# Altium schematic sheet 03.ETC.SchDoc
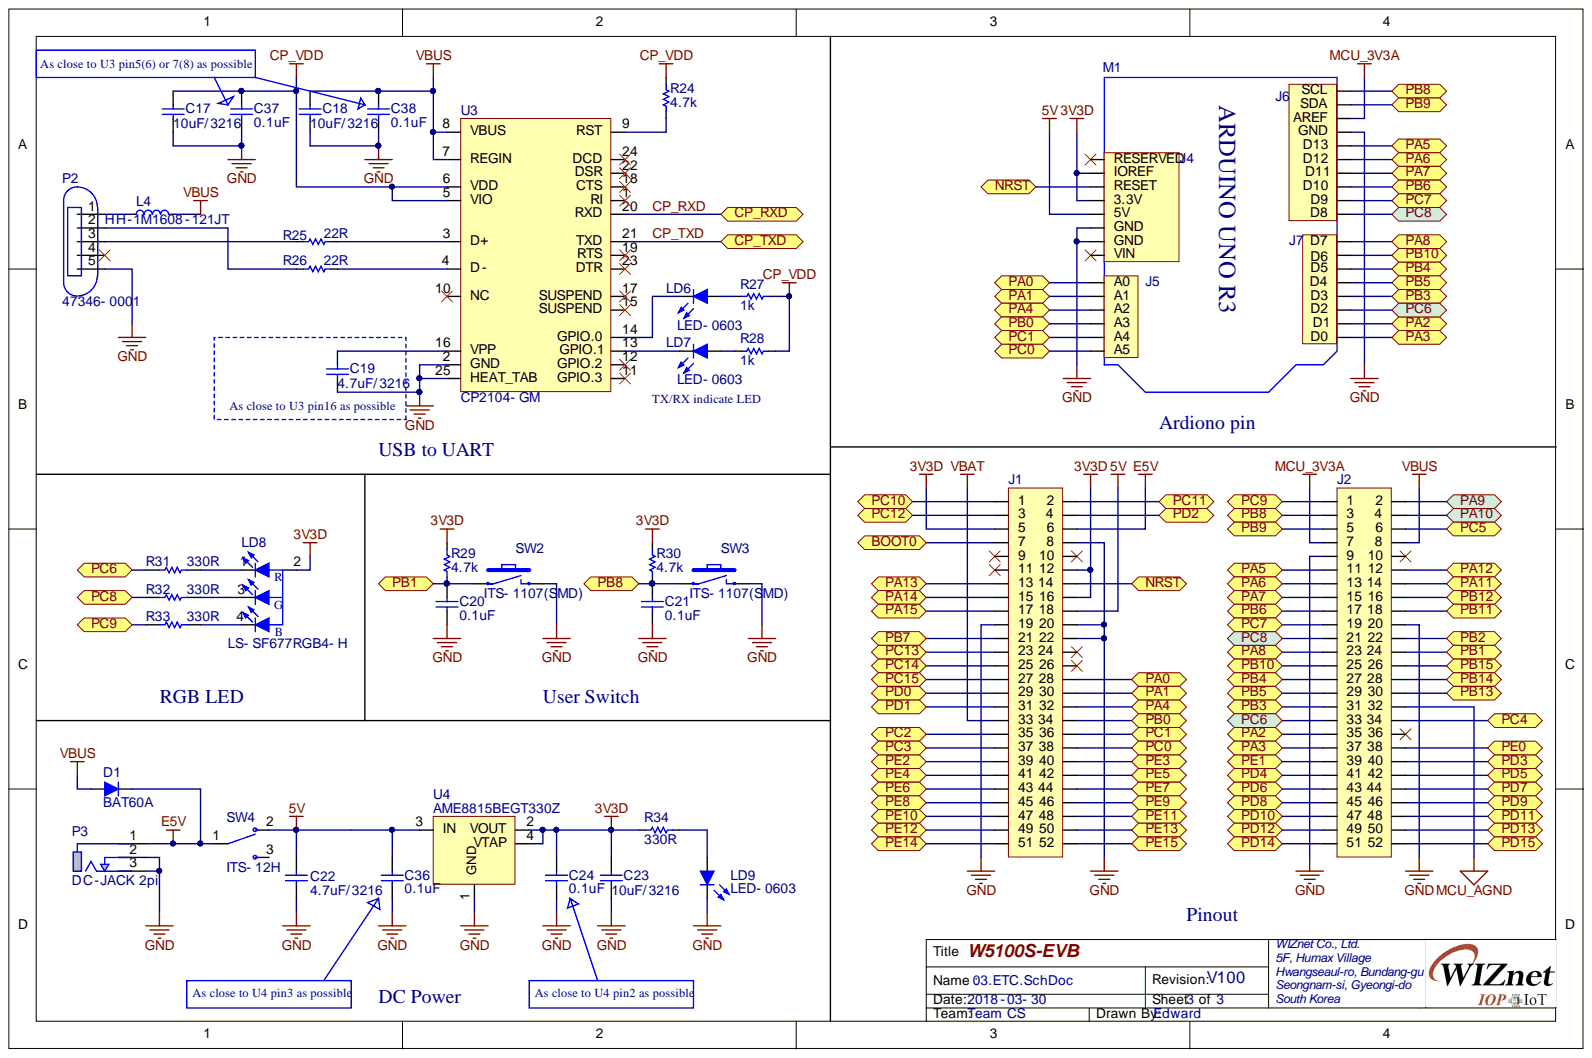
[diagram p0: 03.ETC.SchDoc]

## Parts
C17  10uF/3216  [CAP-1206]
C18  10uF/3216  [CAP-1206]
C19  4.7uF/3216  [CAP-1206]
C20  0.1uF  [CAP-0603]
C21  0.1uF  [CAP-0603]
C22  4.7uF/3216  [CAP-1206]
C23  10uF/3216  [CAP-1206]
C24  0.1uF  [CAP-0603]
C36  0.1uF  [CAP-0603]
C37  0.1uF  [CAP-0603]
C38  0.1uF  [CAP-0603]
D1  BAT60A  [SOD-323(1-C,2-A)]
J1  2.54mm 2x26 Pin Header DIP  [IDC-2X26]  (value not drawn)
J2  2.54mm 2x26 Pin Header DIP  [IDC-2X26]  (value not drawn)
L4  HH-1M1608-121JT  [IND-0603]
LD6  LED-0603  [LED-0603-RED]
LD7  LED-0603  [LED-0603-RED]
LD8  LS-SF677RGB4-H  [LED-LS-SF677RGB4-H]
LD9  LED-0603  [LED-0603-RED]
M1  ARDUINO UNO R3_1  [ARDUINOR3_ICSP(3)]  (value not drawn)
P2  47346-0001  [USB-MOLEX-47346]
P3  DC-JACK 2pi  [DC-JACK 2pi]
R24  4.7k  [RES-0603]
R25  22R  [RES-0603]
R26  22R  [RES-0603]
R27  1k  [RES-0603]
R28  1k  [RES-0603]
R29  4.7k  [RES-0603]
R30  4.7k  [RES-0603]
R31  330R  [RES-0603]
R32  330R  [RES-0603]
R33  330R  [RES-0603]
R34  330R  [RES-0603]
SW2  ITS-1107(SMD)  [IT-1107(SMD)]
SW3  ITS-1107(SMD)  [IT-1107(SMD)]
SW4  ITS-12H  [ITS-12H]
U3  CP2104-GM  [QFN24(0.5/4X4)]
U4  AME8815BEGT330Z  [SOT-223]

## Ports
BOOT0, CP_RXD, CP_TXD, NRST, PA0, PA1, PA2, PA3, PA4, PA5, PA6, PA7, PA8, PA9, PA10, PA11, PA12, PA13, PA14, PA15, PB0, PB1, PB2, PB3, PB4, PB5, PB6, PB7, PB8, PB9, PB10, PB11, PB12, PB13, PB14, PB15, PC0, PC1, PC2, PC3, PC4, PC5, PC6, PC7, PC8, PC9, PC10, PC11, PC12, PC13, PC14, PC15, PD0, PD1, PD2, PD3, PD4, PD5, PD6, PD7, PD8, PD9, PD10, PD11, PD12, PD13, PD14, PD15, PE0, PE1, PE2, PE3, PE4, PE5, PE6, PE7, PE8, PE9, PE10, PE11, PE12, PE13, PE14, PE15

## Nets
3V3D: C23.2 C24.2 J1.5 J1.12 J1.16 LD8.2 M1.3.3V M1.IOREF R29.2 R30.2 R34.1 U4.2(VOUT) U4.4(VTAP)
5V: C22.2 C36.2 J1.18 M1.5V SW4.2 U4.3(IN)
CP_VDD: C17.2 C37.2 R24.2 R27.1 R28.1 U3.5(VIO) U3.6(VDD)
E5V: D1.1 J1.6 P3.1 SW4.1
GND: C17.1 C18.1 C19.1 C20.1 C21.1 C22.1 C23.1 C24.1 C36.1 C37.1 C38.1 J1.8 J1.19 J1.20 J1.22 J2.9 J2.20 LD9.2 M1.GND1(GND) M1.GND2(GND) M1.GND4(GND) P2.5 P2.6 P2.7 P2.8 P2.9 P2.10 P2.11 P3.2 P3.3 SW2.2 SW3.2 U3.2(GND) U3.25(HEAT_TAB) U4.1(GND)
MCU_3V3A: J2.7 M1.AREF
MCU_AGND: J2.32
VBAT: J1.33
VBUS: C18.2 C38.2 D1.2 J2.8 L4.2 U3.7(REGIN) U3.8(VBUS)
BOOT0: J1.7 ; port BOOT0
CP_RXD: U3.20(RXD) ; port CP_RXD
CP_TXD: U3.21(TXD) ; port CP_TXD
NRST: J1.14 M1.\RESET ; port NRST
PA0: J1.28 M1.A0 ; port PA0
PA1: J1.30 M1.A1 ; port PA1
PA2: J2.35 M1.D1 ; port PA2
PA3: J2.37 M1.D0 ; port PA3
PA4: J1.32 M1.A2 ; port PA4
PA5: J2.11 M1.D13 ; port PA5
PA6: J2.13 M1.D12 ; port PA6
PA7: J2.15 M1.D11 ; port PA7
PA8: J2.23 M1.D7 ; port PA8
PA9: J2.2 ; port PA9
PA10: J2.4 ; port PA10
PA11: J2.14 ; port PA11
PA12: J2.12 ; port PA12
PA13: J1.13 ; port PA13
PA14: J1.15 ; port PA14
PA15: J1.17 ; port PA15
PB0: J1.34 M1.A3 ; port PB0
PB1: C20.2 J2.24 R29.1 SW2.1 ; port PB1
PB2: J2.22 ; port PB2
PB3: J2.31 M1.D3 ; port PB3
PB4: J2.27 M1.D5 ; port PB4
PB5: J2.29 M1.D4 ; port PB5
PB6: J2.17 M1.D10 ; port PB6
PB7: J1.21 ; port PB7
PB8: C21.2 J2.3 M1.SCL R30.1 SW3.1 ; port PB8
PB9: J2.5 M1.SDA ; port PB9
PB10: J2.25 M1.D6 ; port PB10
PB11: J2.18 ; port PB11
PB12: J2.16 ; port PB12
PB13: J2.30 ; port PB13
PB14: J2.28 ; port PB14
PB15: J2.26 ; port PB15
PC0: J1.38 M1.A5 ; port PC0
PC1: J1.36 M1.A4 ; port PC1
PC2: J1.35 ; port PC2
PC3: J1.37 ; port PC3
PC4: J2.34 ; port PC4
PC5: J2.6 ; port PC5
PC6: J2.33 M1.D2 R31.1 ; port PC6
PC7: J2.19 M1.D9 ; port PC7
PC8: J2.21 M1.D8 R32.1 ; port PC8
PC9: J2.1 R33.1 ; port PC9
PC10: J1.1 ; port PC10
PC11: J1.2 ; port PC11
PC12: J1.3 ; port PC12
PC13: J1.23 ; port PC13
PC14: J1.25 ; port PC14
PC15: J1.27 ; port PC15
PD0: J1.29 ; port PD0
PD1: J1.31 ; port PD1
PD2: J1.4 ; port PD2
PD3: J2.40 ; port PD3
PD4: J2.41 ; port PD4
PD5: J2.42 ; port PD5
PD6: J2.43 ; port PD6
PD7: J2.44 ; port PD7
PD8: J2.45 ; port PD8
PD9: J2.46 ; port PD9
PD10: J2.47 ; port PD10
PD11: J2.48 ; port PD11
PD12: J2.49 ; port PD12
PD13: J2.50 ; port PD13
PD14: J2.51 ; port PD14
PD15: J2.52 ; port PD15
PE0: J2.38 ; port PE0
PE1: J2.39 ; port PE1
PE2: J1.39 ; port PE2
PE3: J1.40 ; port PE3
PE4: J1.41 ; port PE4
PE5: J1.42 ; port PE5
PE6: J1.43 ; port PE6
PE7: J1.44 ; port PE7
PE8: J1.45 ; port PE8
PE9: J1.46 ; port PE9
PE10: J1.47 ; port PE10
PE11: J1.48 ; port PE11
PE12: J1.49 ; port PE12
PE13: J1.50 ; port PE13
PE14: J1.51 ; port PE14
PE15: J1.52 ; port PE15
NetC19_2: C19.2 U3.16(VPP)
NetL4_1: L4.1 P2.1
NetLD6_1: LD6.1 R27.2
NetLD6_2: LD6.2 U3.14(GPIO.0)
NetLD7_1: LD7.1 R28.2
NetLD7_2: LD7.2 U3.13(GPIO.1)
NetLD8_1: LD8.1 R31.2
NetLD8_3: LD8.3 R32.2
NetLD8_4: LD8.4 R33.2
NetLD9_1: LD9.1 R34.2
NetP2_2: P2.2 R26.1
NetP2_3: P2.3 R25.1
NetR24_1: R24.1 U3.9(RST)
NetR25_2: R25.2 U3.3(D+)
NetR26_2: R26.2 U3.4(D-)

Not connected: J1 9 10 11 24 26; J2 10 36; M1 RESERVED VIN; P2 4; SW4 3; U3 1(RI) 10(NC) 11(GPIO.3) 12(GPIO.2) 15(SUSPEND) 17(SUSPEND) 18(CTS) 19(RTS) 22(DSR) 23(DTR) 24(DCD)

## Library data of the parts
C17-C19 C22 C23 = CAP-1206: Ceramic CAP 1206(3216); Component Kind: Standard; Library Name: Scott_lib.IntLib
C20 C21 C24 C36-C38 = CAP-0603: Ceramic CAP 0603(1608); Component Kind: Standard; Library Name: Scott_lib.IntLib
D1 = DIODE-BAT60A: Silicon Schottky Diode; Component Kind: Standard; Library Name: Scott_lib.IntLib
L4 = IND-0603: Inductor 0603(1608); Component Kind: Standard; Library Name: Scott_lib.IntLib
LD8 = LED-LS-SF677RGB4-H: 3.5*2.8*1.9mm Surface Mount LED, RGB Full Color; Component Kind: Standard; Library Name: Scott_lib.IntLib
M1 = ARDUINO UNO R3_1: Arduino UNO R3; Component Kind: Standard; Library Name: Scott_lib.IntLib
P2 = 47346-0001: Micro USB B Connector SMD; Component Kind: Standard; Library Name: Scott_lib.IntLib
R24-R30 R34 = RES-0603: RES0603; Component Kind: Standard; Library Name: Scott_lib.IntLib
R31-R33 = RES-0603: Component Kind: Standard; Description: RES-0603; Library Name: Scott_lib.IntLib
SW2 SW3 = ITS-1107(SMD): TACT Switch (SMD); Component Kind: Standard; Library Name: Scott_lib.IntLib
SW4 = ITS-12H: Slide Switch; Component Kind: Standard; Library Name: Scott_lib.IntLib
U3 = CP2104-GM: SINGLE-CHIP USB-TO-UART BRIDGE; Component Kind: Standard; Library Name: Scott_lib.IntLib
U4 = AME8815BEGT330Z: 1.5A CMOS LDO; Component Kind: Standard; Library Name: Scott_lib.IntLib
J1 J2 = 2.54mm 2x26 Pin Header DIP: Component Kind: Standard; Description: 2.54mm 2x26 Pin Header DIP; Library Name: Scott_lib.IntLib
LD6 LD7 LD9 = LED-0603: Component Kind: Standard; Description: LED-0603; Library Name: Scott_lib.IntLib
P3 = DC-JACK 2pi: Component Kind: Standard; Description: DC-JACK 2pi; Library Name: Scott_lib.IntLib
